# Altium schematic sheet [03] - POWER SUPPLY.SchDoc
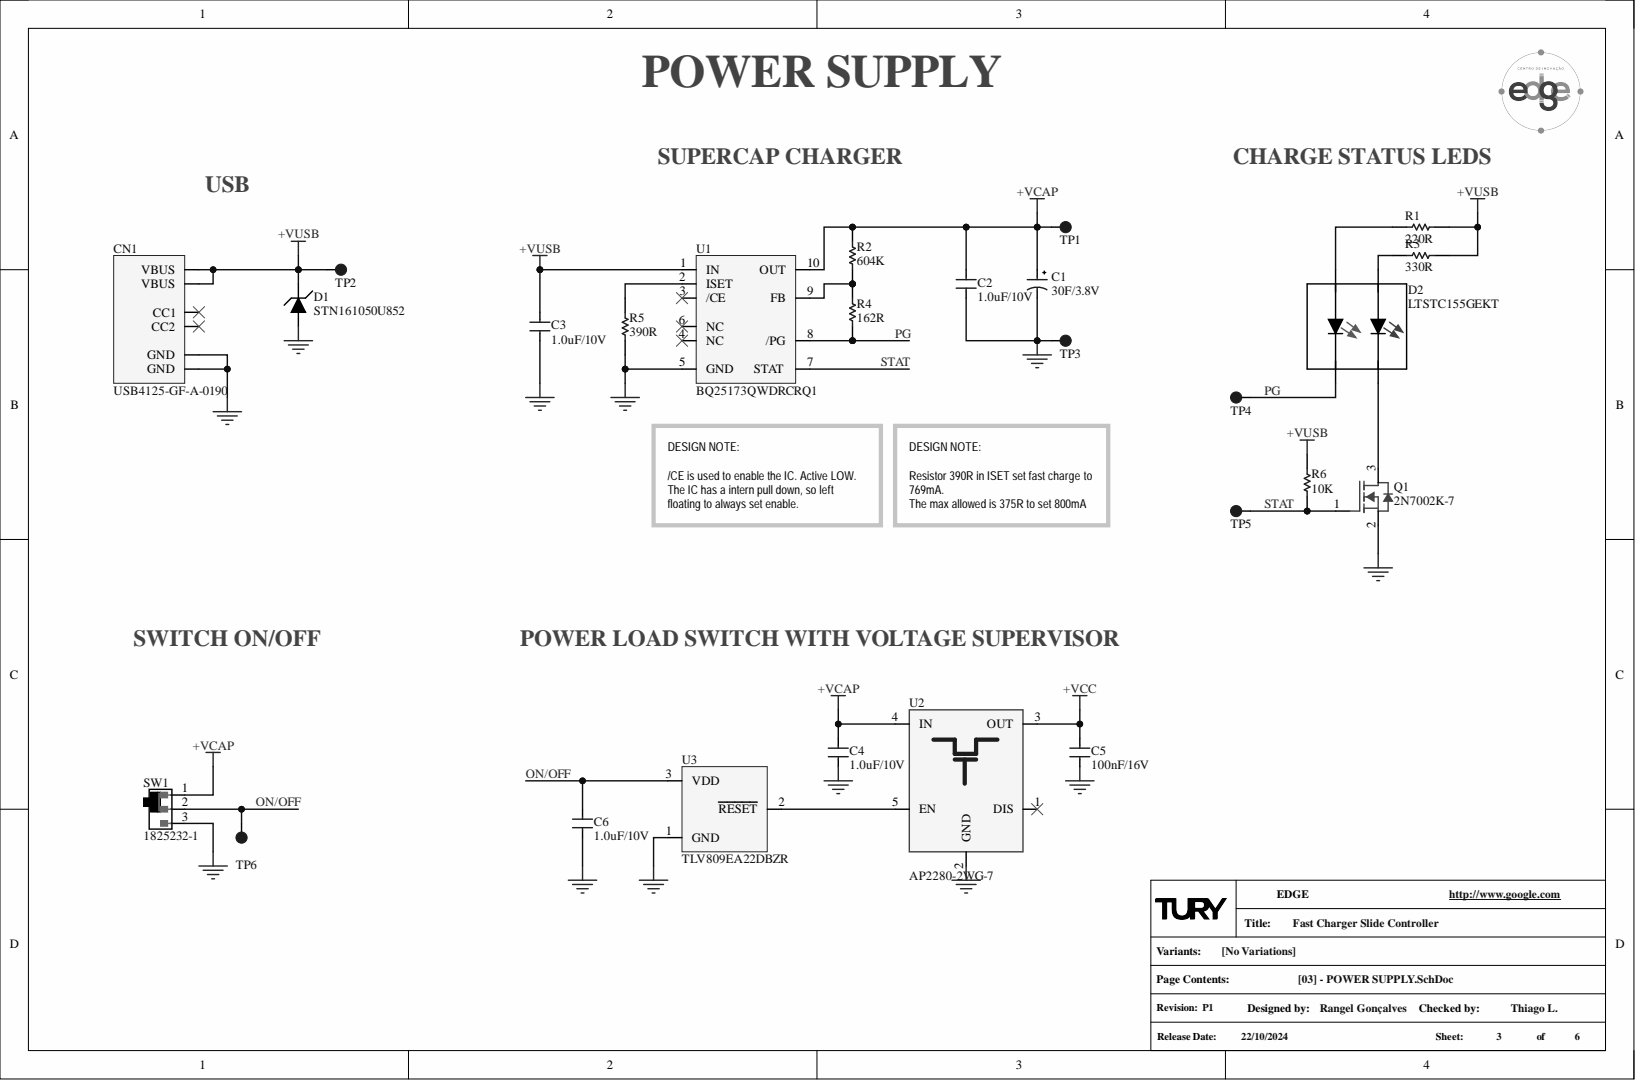
[diagram p0: [03] - POWER SUPPLY.SchDoc]

## Parts
C1  30F/3.8V  [DGH156Q2R7 - plane]
C2  1.0uF/10V  [C0402]
C3  1.0uF/10V  [C0402]
C4  1.0uF/10V  [C0402]
C5  100nF/16V  [C0402]
C6  1.0uF/10V  [C0402]
CN1  USB4125-GF-A-0190  [USB4125-GF-A-0190]
D1  STN161050U852  [STN161050U852]
D2  LTSTC155GEKT  [LTST-C155GEKT]
Q1  2N7002K-7  [SOT90P240X115-3N]
R1  220R  [R0402]
R2  604K  [R0402]
R3  330R  [R0402]
R4  162R  [R0603]
R5  390R  [R0402]
R6  10K  [R0402]
SW1  1825232-1  [1825232-1_TEC]
TP1  +VCAP  [Test Point]  (no BOM)
TP2  +VUSB  [Test Point]  (no BOM)
TP3  GND  [Test Point]  (value not drawn)  (no BOM)
TP4  PG  [Test Point]  (no BOM)
TP5  STAT  [Test Point]  (no BOM)
TP6  ON/OFF  [Test Point]  (no BOM)
U1  BQ25173QWDRCRQ1  [SON50P300X100_HS-11N]
U2  AP2280-2WG-7  [SOT95P285X145-5N]
U3  TLV809EA22DBZR  [SOT90P240X115-3N23]

## Nets
+VCAP: C1.1 C2.1 C4.2 R2.2 SW1.1 TP1.1 U1.10(OUT) U2.4(IN)
+VCC: C5.2 U2.3(OUT)
+VUSB: C3.1 CN1.A9(VBUS) CN1.B9(VBUS) D1.1 R1.2 R3.2 R6.2 TP2.1 U1.1(IN)
GND: C1.2 C2.2 C3.2 C4.1 C5.1 C6.1 CN1.A12(GND) CN1.B12(GND) D1.2 Q1.2 R5.1 SW1.3 TP3.1 U1.5(GND) U2.2(GND) U3.1
ON/OFF: C6.2 SW1.2 TP6.1 U3.3
PG: D2.3 R4.1 TP4.1 U1.8(/PG)
STAT: Q1.1 R6.1 TP5.1 U1.7(STAT)
NetD2_1: D2.1 R1.1
NetD2_2: D2.2 R3.1
NetD2_4: D2.4 Q1.3
NetR2_1: R2.1 R4.2 U1.9(FB)
NetR5_2: R5.2 U1.2(ISET)
NetU2_5: U2.5(EN) U3.2

Not connected: CN1 A5(CC1) B5(CC2); U1 3(/CE) 4(NC) 6(NC); U2 1(DIS)

## Library data of the parts
C1 = TPLC-3R8/30MR10X16: SCAP - 30F 3.8V PTH 20%; Manufacturer 1: Tecate Group; .Mounting: PTH; Component Kind: Standard; ComponentLink1Description: https://www.tecategroup.com/products/data_sheet.php?i=TPLC-3R8/30MR10X16&src-supplier=Digikey; ComponentLink1URL: https://www.tecategroup.com/products/data_sheet.php?i=TPLC-3R8/30MR10X16&src-supplier=Digikey; Manufacturer Part Number 1: TPLC-3R8/30MR10X16; Pricing: 1=3,48, 25=2,6344, 50=2,4104, 100=2,074, 450=1,6256, 950=1,51348, 2400=1,45743, 4900=1,4294 (USD); RoHS: No; Stock: 9186; Supplier 1: Digikey; Supplier Part Number 1: 2085-TPLC-3R8/30MR10X16-ND; Library Name: fast_charger_slide_controller_P2.SchLib
C2-C4 C6 = CL05X105KP5NNNC: MLCC - 1µF 10V 0402 X6R ±10%.; Manufacturer 1: Samsung; .Mounting: SMT; Capacitor Type: Ceramic; Multilayer: Yes; Temperature Characteristics Code: X6S; Tolerance  (fill in as +-): 10%; Value: 1uF; Case/Package: 0402; Category: Ceramic Capacitors; Component Kind: Standard; ComponentLink1Description: https://datasheet.ciiva.com:443/pdfs/VipMasterIC/IC/SEMS/SEMS-S-A0008522793/SEMS-S-A0008522935-1.pdf?src-supplier=IHS+Markit; ComponentLink1URL: https://datasheet.ciiva.com:443/pdfs/VipMasterIC/IC/SEMS/SEMS-S-A0008522793/SEMS-S-A0008522935-1.pdf?src-supplier=IHS+Markit; ComponentLink2Description: http://www.samsungsem.com/kr/support/library/product-catalog/mlcc.jsp?src-supplier=Digikey; ComponentLink2URL: http://www.samsungsem.com/kr/support/library/product-catalog/mlcc.jsp?src-supplier=Digikey; ComponentLink3Description: http://www.samsungsem.com/kr/support/product-search/mlcc/CL05X105KP5NNNC.jsp?src-supplier=Digikey; ComponentLink3URL: http://www.samsungsem.com/kr/support/product-search/mlcc/CL05X105KP5NNNC.jsp?src-supplier=Digikey; ComponentLink4Description: http://www.samsungsem.com/global/front/downloadcms.do?path=%2F%2Fglobal%2F%2Fsupport%2F%2Flibrary%2F%2Fproduct-catalog%2F%2F__icsFiles%2F%2Fafieldfile%2F%2F2014%2F%2F11%2F%2F13&fileName=MLCC.pdf&src-supplier=Avnet; ComponentLink4URL: http://www.samsungsem.com/global/front/downloadcms.do?path=%2F%2Fglobal%2F%2Fsupport%2F%2Flibrary%2F%2Fproduct-catalog%2F%2F__icsFiles%2F%2Fafieldfile%2F%2F2014%2F%2F11%2F%2F13&fileName=MLCC.pdf&src-supplier=Avnet; ComponentLink5Description: https://datasheet.octopart.com/CL05X105KP5NNNC-Samsung-Electro-Mechanics-datasheet-115064993.pdf?src-supplier=Future+Electronics; ComponentLink5URL: https://datasheet.octopart.com/CL05X105KP5NNNC-Samsung-Electro-Mechanics-datasheet-115064993.pdf?src-supplier=Future+Electronics; Dielectric Material: Ceramic; Height: 0.55mm; JESD-609 Code: e3; Length: 1mm; Manufacturer Part Number 1: CL05X105KP5NNNC; Max Operating Temperature: 105°C; Min Operating Temperature: -55°C; Mounting Technology: Surface Mount; Package Shape: Rectangular; Package Style: SMTMeter; Packaging: Tape and Reel; Pins: 2; Pricing: 10000=0,00801 (USD); Rated DC Voltage (URdc): 10V; Reach SVHC Compliant: Yes; RoHS: Yes; RoHS Compliant: Yes; Stock: 10000; Supplier 1: Avnet; Supplier Part Number 1: CL05X105KP5NNNC; Terminal Finish: Nickel; Termination: Wraparound; Tolerance (fill in as +-): 10%; Width: 0.5mm; Library Name: fast_charger_slide_controller_P2.SchLib
C5 = KGM05AR71C104KH: MLCC - 100nF 16V 0402 X7R ±10%.; Manufacturer 1: Kyocera AVX; .Mounting: SMT; .PCBChecked: Lucas Vieira; Category 1: Ceramic Capacitors; Description 1: Cap Cer 0.1UF 16V X7R 0402; Component Kind: Standard; Manufacturer 2: Murata; Manufacturer 3: Taiyo Yuden; Manufacturer Part Number 1: KGM05AR71C104KH; Manufacturer Part Number 2: GRM155R71E104KE14J; Manufacturer Part Number 3: EMK105B7104KV-F; Pricing 1: 1=0,1, 10=0,014, 50=0,008, 100=0,0062, 500=0,00468, 1000=0,00368, 2500=0,00335, 5000=0,00308 (USD); RoHS 1: No; Stock 1: 2329199; Supplier 1: Digikey; Supplier Part Number 1: 478-KGM05AR71C104KHCT-ND; .SCHChecked: Lucas Vieira; Library Name: fast_charger_slide_controller_P2.SchLib
CN1 = USB4125-GF-A-0190: CONN - CONN RCPT USB TYPE C 6 POS; Manufacturer 1: Global Connector Technology; .Mounting: PTH; Category: USB Connectors; Component Kind: Standard; ComponentLink1Description: https://datasheet.octopart.com/USB4125-GF-A-0190-GCT-datasheet-166929327.pdf?src-supplier=TME; ComponentLink1URL: https://datasheet.octopart.com/USB4125-GF-A-0190-GCT-datasheet-166929327.pdf?src-supplier=TME; Manufacturer Part Number 1: USB4125-GF-A-0190; Pricing: 1=0,67, 10=0,586, 25=0,5356, 50=0,5042, 100=0,4832, 250=0,44116, 500=0,42016 (USD); RoHS: No; Stock: 8194; Supplier 1: Digikey; Supplier Part Number 1: 2073-USB4125-GF-A-0190CT-ND; Library Name: fast_charger_slide_controller_P2.SchLib
D1 = STN161050U852: DIODE - TVS ESD Supp., DFN1610-2L, 5 V | Eaton Electronics STN161050U852; Manufacturer 1: Eaton Bussmann; .Mounting: SMT; .PCBChecked: Victor H; Category: TVS Diodes; Component Kind: Standard; Manufacturer Part Number 1: STN161050U852; Pricing 1: 1=0,5, 10=0,356, 100=0,1796, 500=0,15918, 1000=0,12387 (USD); RoHS: No; Stock 1: 9683; Supplier 1: Digikey; Supplier Part Number 1: 283-STN161050U852CT-ND; .SCHChecked: Victor H; Library Name: fast_charger_slide_controller_P2.SchLib
D2 = LTSTC155GEKT: DIODE - LED BI-COLOR GREEN/RED 4-PIN; Manufacturer 1: Vishay Lite-On; .Mounting: SMT; Component Kind: Standard; ComponentLink1Description: https://datasheet.octopart.com/LTST-C155GEKT-Lite-On-datasheet-158360.pdf?src-supplier=Future+Electronics; ComponentLink1URL: https://datasheet.octopart.com/LTST-C155GEKT-Lite-On-datasheet-158360.pdf?src-supplier=Future+Electronics; Manufacturer Part Number 1: LTSTC155GEKT; Pricing: 876=0,1142, 1000=0,0632 (USD); RoHS: No; Stock: 2543; Supplier 1: Arrow Electronics; Supplier Part Number 1: LTST-C155GEKT; Library Name: fast_charger_slide_controller_P2.SchLib
Q1 = 2N7002K-7: TRANS - MOSFET N-Channel 60V 0.3A SOT-23.; Manufacturer 1: Diodes; .Mounting: SMT; Additional Feature: HIGH RELIABILITY; Drain Current-Max (Abs) (ID): 300mA; Drain-source On Resistance-Max: 2R; Feedback Cap-Max (Crss): 5pF; FET Technology: METAL-OXIDE SEMICONDUCTOR; Number of Elements: 1; Operating Mode: ENHANCEMENT MODE; Package Body Material: Plastic; Power Dissipation-Max (Abs): 350mW; Qualification Status: Not Qualified; Time @ Peak Reflow Temperature-Max (s): 40s; Transistor Application: SWITCHING; Transistor Element Material: SILICON; Category: MOSFETs; Component Kind: Standard; ComponentLink1Description: https://datasheet.ciiva.com:443/pdfs/VipMasterIC/IC/DIOD/DIOD-S-A0009689736/DIOD-S-A0009691901-1.pdf?src-supplier=IHS+Markit; ComponentLink1URL: https://datasheet.ciiva.com:443/pdfs/VipMasterIC/IC/DIOD/DIOD-S-A0009689736/DIOD-S-A0009691901-1.pdf?src-supplier=IHS+Markit; ComponentLink2Description: https://datasheet.ciiva.com/1254/ds30896-1254496.pdf?src-supplier=Newark; ComponentLink2URL: https://datasheet.ciiva.com/1254/ds30896-1254496.pdf?src-supplier=Newark; ComponentLink3Description: https://datasheet.ciiva.com/25624/0900766b81151e8c-25624936.pdf?src-supplier=Rs; ComponentLink3URL: https://datasheet.ciiva.com/25624/0900766b81151e8c-25624936.pdf?src-supplier=Rs; ComponentLink4Description: https://datasheet.ciiva.com/4444/ds30896-81375-4444887.pdf?src-supplier=Mouser; ComponentLink4URL: https://datasheet.ciiva.com/4444/ds30896-81375-4444887.pdf?src-supplier=Mouser; ComponentLink5Description: https://datasheet.ciiva.com/15137/getdatasheetpartid-75159-15137378.pdf?src-supplier=Verical; ComponentLink5URL: https://datasheet.ciiva.com/15137/getdatasheetpartid-75159-15137378.pdf?src-supplier=Verical; ComponentLink6Description: https://datasheet.ciiva.com/48532/ds30896-48532645.pdf?src-supplier=Avnet; ComponentLink6URL: https://datasheet.ciiva.com/48532/ds30896-48532645.pdf?src-supplier=Avnet; ComponentLink7Description: https://datasheet.ciiva.com/1188/ds30896-1188422.pdf?src-supplier=Element14; ComponentLink7URL: https://datasheet.ciiva.com/1188/ds30896-1188422.pdf?src-supplier=Element14; ComponentLink8Description: https://datasheet.ciiva.com/16200/ds30896-16200842.pdf?src-supplier=Future+Electronics; ComponentLink8URL: https://datasheet.ciiva.com/16200/ds30896-16200842.pdf?src-supplier=Future+Electronics; Drain Current-Max (ID): 300mA; DS Breakdown Voltage-Min: 60V; JESD-30 Code: R-PDSO-G3; JESD-609 Code: e3; Manufacturer Part Number 1: 2N7002K-7; Max Operating Temperature: 150°C; Moisture Sensitivity Level: 1; Package Shape: Rectangular; Package Style: SMALL OUTLINEMeter; Peak Reflow Temperature (Cel): 260°C; Pins: 3; Polarity/Channel Type: N-CHANNEL; Pricing: 3000=0,0186, 9000=0,0179, 30000=0,0172, 60000=0,0168, 120000=0,0161 (USD); Reach SVHC Compliant: Yes; RoHS: Yes; RoHS Compliant: Yes; Stock: 2232000; Supplier 1: Future Electronics; Supplier Part Number 1: 8672685; Surface Mount: Yes; Terminal Finish: Tin; Terminal Form: Gull Wing; Terminal Position: DUAL; Library Name: fast_charger_slide_controller_P2.SchLib
R1 = CRCW0402220RFKEDC: RES - 220R 1/16W 0402 ±1%; Manufacturer 1: Vishay; .Mounting: SMT; Package Height: 0.3mm; Package Length: 1mm; Package Width: 0.5mm; Power: 63mW; Temperature Coefficient: -100100ppm/°C; Working Voltage: 50V; Case/Package: 0402; Category: Chip SMD Resistors; Component Kind: Standard; ComponentLink1Description: https://datasheet.ciiva.com:443/pdfs/VipMasterIC/IC/VISH/VISH-S-A0003599164/VISH-S-A0003599164-1.pdf?src-supplier=IHS+Markit; ComponentLink1URL: https://datasheet.ciiva.com:443/pdfs/VipMasterIC/IC/VISH/VISH-S-A0003599164/VISH-S-A0003599164-1.pdf?src-supplier=IHS+Markit; ComponentLink2Description: http://www.vishay.com/docs/28773/crcwce3.pdf?src-supplier=Digikey; ComponentLink2URL: http://www.vishay.com/docs/28773/crcwce3.pdf?src-supplier=Digikey; ComponentLink3Description: https://datasheet.octopart.com/CRCW0402220RFKEDC-Vishay-datasheet-100063072.pdf?src-supplier=Future+Electronics; ComponentLink3URL: https://datasheet.octopart.com/CRCW0402220RFKEDC-Vishay-datasheet-100063072.pdf?src-supplier=Future+Electronics; Construction: Chip; JESD-609 Code: e3; Manufacturer Part Number 1: CRCW0402220RFKEDC; Max Operating Temperature: 155°C; Min Operating Temperature: -55°C; Package Style: SMTMeter; Packaging: Tape and Reel; Pins: 2; Pricing: 1=0,1, 10=0,016, 50=0,016, 100=0,009, 1000=0,006, 10000=0,003 (USD); Reach SVHC Compliant: Yes; Resistor Type: FIXED RESISTOR; RoHS: Yes; RoHS Compliant: Yes; Stock: 82052; Supplier 1: Mouser; Supplier Part Number 1: 71-CRCW0402220RFKEDC; Technology: METAL GLAZE/THICK FILM; Terminal Finish: Nickel; Tolerance: 1%; Value: 220R; Library Name: fast_charger_slide_controller_P2.SchLib
R2 = ERJ-2RKF6043X: RES - 604K 1/10W 0402 ±1%; Manufacturer 1: Panasonic; .Mounting: SMT; Max Power Dissipation: 100mW; Temperature Coefficient: 100ppm/°C; Value: 604kR; Voltage Rating: 50V; Case Code (Imperial): 0402; Case Code (Metric): 1005; Case/Package: 0402; Category: Chip SMD Resistors; Component Kind: Standard; ComponentLink1Description: https://datasheet.ciiva.com/22764/1678782-22764925.pdf?src-supplier=Farnell; ComponentLink1URL: https://datasheet.ciiva.com/22764/1678782-22764925.pdf?src-supplier=Farnell; ComponentLink2Description: https://datasheet.ciiva.com/43467/1678782-43467557.pdf?src-supplier=Farnell; ComponentLink2URL: https://datasheet.ciiva.com/43467/1678782-43467557.pdf?src-supplier=Farnell; ComponentLink3Description: https://datasheet.ciiva.com/9180/aoa0000ce2-9180923.pdf?src-supplier=Avnet; ComponentLink3URL: https://datasheet.ciiva.com/9180/aoa0000ce2-9180923.pdf?src-supplier=Avnet; ComponentLink4Description: https://datasheet.ciiva.com/22668/1678782-22668395.pdf?src-supplier=Element14; ComponentLink4URL: https://datasheet.ciiva.com/22668/1678782-22668395.pdf?src-supplier=Element14; ComponentLink5Description: https://datasheet.ciiva.com/16769/70260748-16769957.pdf?src-supplier=Allied+Electronics; ComponentLink5URL: https://datasheet.ciiva.com/16769/70260748-16769957.pdf?src-supplier=Allied+Electronics; ComponentLink6Description: https://datasheet.ciiva.com/16787/70260748-16787162.pdf?src-supplier=Allied+Electronics; ComponentLink6URL: https://datasheet.ciiva.com/16787/70260748-16787162.pdf?src-supplier=Allied+Electronics; Contact Plating: Tin; Features: Automotive AEC-Q200; Height: 0.35mm; Lead Free: Lead Free; Length: 1mm; Manufacturer Part Number 1: ERJ-2RKF6043X; Max Operating Temperature: 155°C; Min Operating Temperature: -55°C; Mounting Technology: Surface Mount; Number of Terminations: 2; Package Quantity: 10000; Packaging: Tape and Reel; Power Rating: 100mW; Pricing: 10000=0,0036, 40000=0,0034, 100000=0,0032, 250000=0,0031, 400000=0,0029 (USD); Ratings: AEC-Q200; Reach SVHC Compliant: Yes; Resistance Tolerance: 1%; Resistor Type: Thick Film; RoHS: Yes; RoHS Compliant: Yes; Series: ERJ; Stock: 160000; Supplier 1: Future Electronics; Supplier Part Number 1: 7126629; Termination: SMD/SMT; Tolerance: 1%; Voltage Rating (DC): 50V; Width: 0.5mm; Library Name: fast_charger_slide_controller_P2.SchLib
R3 = RC0402FR-07330RL: RES - 330R 1/16W 0402 ±1%; Manufacturer 1: Yageo; .Mounting: SMT; Additional Feature: LASER TRIMMABLE; Package Height: 0.35mm; Package Length: 1mm; Package Width: 0.5mm; Power: 62.5mW; Rated Temperature: 70°C; Temperature Coefficient: 100ppm/°C; Working Voltage: 50V; Case/Package: 0402; Category: Chip SMD Resistors; Component Kind: Standard; ComponentLink1Description: https://datasheet.ciiva.com:443/pdfs/VipMasterIC/IC/YAGO/YAGO-S-A0008145938/YAGO-S-A0008145938-1.pdf?src-supplier=IHS+Markit; ComponentLink1URL: https://datasheet.ciiva.com:443/pdfs/VipMasterIC/IC/YAGO/YAGO-S-A0008145938/YAGO-S-A0008145938-1.pdf?src-supplier=IHS+Markit; ComponentLink2Description: https://datasheet.ciiva.com/11819/0900766b80c66297-11819490.pdf?src-supplier=Rs; ComponentLink2URL: https://datasheet.ciiva.com/11819/0900766b80c66297-11819490.pdf?src-supplier=Rs; ComponentLink3Description: https://datasheet.ciiva.com/7849/1018594-7849962.pdf?src-supplier=Element14; ComponentLink3URL: https://datasheet.ciiva.com/7849/1018594-7849962.pdf?src-supplier=Element14; ComponentLink4Description: https://datasheet.ciiva.com/7849/1018594-7849963.pdf?src-supplier=Element14; ComponentLink4URL: https://datasheet.ciiva.com/7849/1018594-7849963.pdf?src-supplier=Element14; ComponentLink5Description: https://datasheet.ciiva.com/12656/pyu-rc0402-51-rohs-l-6-r-12656815.pdf?src-supplier=Mouser; ComponentLink5URL: https://datasheet.ciiva.com/12656/pyu-rc0402-51-rohs-l-6-r-12656815.pdf?src-supplier=Mouser; ComponentLink6Description: https://datasheet.ciiva.com/2453/pyu-r-int-thick-7-2453661.pdf?src-supplier=Digikey; ComponentLink6URL: https://datasheet.ciiva.com/2453/pyu-r-int-thick-7-2453661.pdf?src-supplier=Digikey; ComponentLink7Description: https://datasheet.ciiva.com/2453/pyu-r-int-thick-7-2453662.pdf?src-supplier=Digikey; ComponentLink7URL: https://datasheet.ciiva.com/2453/pyu-r-int-thick-7-2453662.pdf?src-supplier=Digikey; ComponentLink8Description: https://datasheet.ciiva.com/2453/pyu-r-int-thick-7-2453663.pdf?src-supplier=Digikey; ComponentLink8URL: https://datasheet.ciiva.com/2453/pyu-r-int-thick-7-2453663.pdf?src-supplier=Digikey; ComponentLink9Description: https://datasheet.ciiva.com/17083/pyu-rc0402-51-rohs-l-6-r-17083994.pdf?src-supplier=Future+Electronics; ComponentLink9URL: https://datasheet.ciiva.com/17083/pyu-rc0402-51-rohs-l-6-r-17083994.pdf?src-supplier=Future+Electronics; Construction: Chip; JESD-609 Code: e3; Manufacturer Part Number 1: RC0402FR-07330RL; Max Operating Temperature: 155°C; Min Operating Temperature: -55°C; Mounting Technology: Surface Mount; Package Shape: Rectangular; Package Style: SMTMeter; Packaging: Tape and Reel; Pins: 2; Pricing: 10000=0,00085 (USD); Reach SVHC Compliant: Yes; Resistor Type: FIXED RESISTOR; RoHS: Yes; RoHS Compliant: Yes; Stock: 330000; Supplier 1: Avnet; Supplier Part Number 1: RC0402FR-07330RL; Technology: METAL GLAZE/THICK FILM; Terminal Finish: Nickel; Termination: Wraparound; Tolerance: 1%; Value: 330R; Library Name: fast_charger_slide_controller_P2.SchLib
R4 = RMCF0603FT162R: RES - 162R 1/10W 0603 ±1%; Manufacturer 1: Stackpole Electronics; .Mounting: SMT; Package Height: 0.45mm; Package Length: 1.55mm; Package Width: 0.8mm; Power: 100mW; Rated Temperature: 70°C; Reference Standard: AEC-Q200; Temperature Coefficient: 100ppm/°C; Working Voltage: 75V; Case/Package: 0603; Category: Chip SMD Resistors; Component Kind: Standard; ComponentLink1Description: https://datasheet.ciiva.com:443/pdfs/VipMasterIC/IC/SEIE/SEIE-S-A0009541969/SEIE-S-A0009541969-1.pdf?src-supplier=IHS+Markit; ComponentLink1URL: https://datasheet.ciiva.com:443/pdfs/VipMasterIC/IC/SEIE/SEIE-S-A0009541969/SEIE-S-A0009541969-1.pdf?src-supplier=IHS+Markit; ComponentLink2Description: https://www.seielect.com/catalog/sei-rmcf_rmcp.pdf?src-supplier=Digikey; ComponentLink2URL: https://www.seielect.com/catalog/sei-rmcf_rmcp.pdf?src-supplier=Digikey; ComponentLink3Description: https://www.seielect.com/catalog/SEI-Packaging.pdf?src-supplier=Digikey; ComponentLink3URL: https://www.seielect.com/catalog/SEI-Packaging.pdf?src-supplier=Digikey; ComponentLink4Description: https://www.seielect.com/catalog/SEI-RMCF_RMCP.pdf?src-supplier=Avnet; ComponentLink4URL: https://www.seielect.com/catalog/SEI-RMCF_RMCP.pdf?src-supplier=Avnet; ComponentLink5Description: https://datasheet.octopart.com/RMCF0603FT162R-Stackpole-Electronics-datasheet-11547379.pdf?src-supplier=Future+Electronics; ComponentLink5URL: https://datasheet.octopart.com/RMCF0603FT162R-Stackpole-Electronics-datasheet-11547379.pdf?src-supplier=Future+Electronics; ComponentLink6Description: http://www.seielect.com/Catalog/SEI-RMC.pdf?src-supplier=Future+Electronics; ComponentLink6URL: http://www.seielect.com/Catalog/SEI-RMC.pdf?src-supplier=Future+Electronics; Construction: Rectangular; JESD-609 Code: e3; Manufacturer Part Number 1: RMCF0603FT162R; Max Operating Temperature: 155°C; Min Operating Temperature: -55°C; Mounting Technology: Surface Mount; Package Shape: Rectangular; Package Style: SMTMeter; Packaging: Tape and Reel; Pins: 2; Pricing: 1=0,1, 10=0,015, 50=0,009, 100=0,0075, 500=0,00498, 1000=0,00425 (USD); Reach SVHC Compliant: Yes; Resistor Type: FIXED RESISTOR; RoHS: Yes; RoHS Compliant: Yes; Stock: 29546; Supplier 1: Digikey; Supplier Part Number 1: RMCF0603FT162RCT-ND; Technology: METAL GLAZE/THICK FILM; Terminal Finish: Nickel; Termination: Wraparound; Tolerance: 1%; Value: 162R; Library Name: fast_charger_slide_controller_P2.SchLib
R5 = CRCW0402390RFKED: RES - 390R 1/16W 0402 ±1%; Manufacturer 1: Vishay; .Mounting: SMT; Max Power Dissipation: 63mW; Temperature Coefficient: 100ppm/°C; Voltage Rating: 50V; Case Code (Imperial): 0402; Case Code (Metric): 1005; Case/Package: 0402; Category: Chip SMD Resistors; Component Kind: Standard; ComponentLink1Description: https://datasheet.ciiva.com/11811/0900766b80d98824-11811074.pdf?src-supplier=Rs; ComponentLink1URL: https://datasheet.ciiva.com/11811/0900766b80d98824-11811074.pdf?src-supplier=Rs; ComponentLink2Description: https://datasheet.ciiva.com/2463/dcrcwe3-2463266.pdf?src-supplier=Digikey; ComponentLink2URL: https://datasheet.ciiva.com/2463/dcrcwe3-2463266.pdf?src-supplier=Digikey; ComponentLink3Description: https://datasheet.ciiva.com/2463/dcrcwe3-2463267.pdf?src-supplier=Digikey; ComponentLink3URL: https://datasheet.ciiva.com/2463/dcrcwe3-2463267.pdf?src-supplier=Digikey; ComponentLink4Description: https://datasheet.ciiva.com/8940/dcrcwe3-8940680.pdf?src-supplier=Avnet; ComponentLink4URL: https://datasheet.ciiva.com/8940/dcrcwe3-8940680.pdf?src-supplier=Avnet; ComponentLink5Description: https://datasheet.ciiva.com/22682/1912744-22682652.pdf?src-supplier=Element14; ComponentLink5URL: https://datasheet.ciiva.com/22682/1912744-22682652.pdf?src-supplier=Element14; ComponentLink6Description: https://datasheet.ciiva.com/7672/1697337-7672799.pdf?src-supplier=Element14; ComponentLink6URL: https://datasheet.ciiva.com/7672/1697337-7672799.pdf?src-supplier=Element14; ComponentLink7Description: https://datasheet.ciiva.com/22708/1697337-22708415.pdf?src-supplier=Element14; ComponentLink7URL: https://datasheet.ciiva.com/22708/1697337-22708415.pdf?src-supplier=Element14; ComponentLink8Description: https://datasheet.ciiva.com/5550/dcrcwe3-109170-5550511.pdf?src-supplier=Mouser; ComponentLink8URL: https://datasheet.ciiva.com/5550/dcrcwe3-109170-5550511.pdf?src-supplier=Mouser; ComponentLink9Description: https://datasheet.ciiva.com/16776/70201119-16776669.pdf?src-supplier=Allied+Electronics; ComponentLink9URL: https://datasheet.ciiva.com/16776/70201119-16776669.pdf?src-supplier=Allied+Electronics; ComponentLink10Description: https://datasheet.ciiva.com/22872/70201119-22872263.pdf?src-supplier=Allied+Electronics; ComponentLink10URL: https://datasheet.ciiva.com/22872/70201119-22872263.pdf?src-supplier=Allied+Electronics; Construction: Rectangular; Contact Plating: Tin; Depth: 0.5mm; Features: Automotive AEC-Q200; Height: 0.35mm; Lead Free: Lead Free; Length: 1mm; Manufacturer Part Number 1: CRCW0402390RFKED; Max Operating Temperature: 155°C; Military Standard: Not; Min Operating Temperature: -55°C; Number of Terminations: 2; Package Quantity: 10000; Packaging: Tape and Reel; Power Rating: 63mW; Pricing: 1=0,1, 10=0,017, 50=0,017, 100=0,01, 1000=0,008, 10000=0,004 (USD); Radiation Hardening: No; Ratings: AEC-Q200; Reach SVHC Compliant: Unknown; Resistance Tolerance: 1%; Resistor Type: Thick Film; RoHS: Yes; RoHS Compliant: Yes; Series: CRCW; Stock: 39159; Supplier 1: Mouser; Supplier Part Number 1: 71-CRCW0402-390-E3; Technology: THICK FILM; Termination: SMD/SMT; Tolerance: 1%; Value: 390R; Voltage Rating (DC): 50V; Weight: 2.3E-05oz; Width: 0.5mm; Library Name: fast_charger_slide_controller_P2.SchLib
R6 = RK73B1ETTP103J: RES - 10K 1/10W 0402 ±5%; Manufacturer 1: KOA Speer; .Mounting: SMT; Package Height: 0.35mm; Package Length: 1mm; Package Width: 0.5mm; Power: 100mW; Rated Temperature: 70°C; Reference Standard: AEC-Q200; Temperature Coefficient: 200ppm/°C; Value: 10kR; Working Voltage: 50V; Case/Package: 0402; Category: Chip SMD Resistors; Component Kind: Standard; ComponentLink1Description: https://datasheet.ciiva.com:443/pdfs/VipMasterIC/IC/KOAS/KOAS-S-A0009586303/KOAS-S-A0009586303-1.pdf?src-supplier=IHS+Markit; ComponentLink1URL: https://datasheet.ciiva.com:443/pdfs/VipMasterIC/IC/KOAS/KOAS-S-A0009586303/KOAS-S-A0009586303-1.pdf?src-supplier=IHS+Markit; ComponentLink2Description: https://datasheet.ciiva.com/15159/getdatasheetpartid-89073-15159879.pdf?src-supplier=Verical; ComponentLink2URL: https://datasheet.ciiva.com/15159/getdatasheetpartid-89073-15159879.pdf?src-supplier=Verical; Construction: Rectangular; JESD-609 Code: e3; Manufacturer Part Number 1: RK73B1ETTP103J; Max Operating Temperature: 155°C; Min Operating Temperature: -55°C; Mounting Technology: Surface Mount; Package Style: SMTMeter; Packaging: Tape and Reel; Pins: 2; Pricing: 1=0,1, 10=0,017, 50=0,0104, 100=0,0087, 500=0,0058, 1000=0,00494, 5000=0,00355 (USD); Reach SVHC Compliant: Yes; Resistor Type: FIXED RESISTOR; RoHS: Yes; RoHS Compliant: Yes; Stock: 1386278; Supplier 1: Digikey; Supplier Part Number 1: 2019-RK73B1ETTP103JCT-ND; Technology: METAL GLAZE/THICK FILM; Terminal Finish: Nickel; Termination: Wraparound; Tolerance: 5%; Library Name: fast_charger_slide_controller_P2.SchLib
SW1 = 1825232-1: SWITCH SLIDE SPDT 200MA 30V; Manufacturer 1: TE Connectivity AMP; .Mounting: PTH; Actuator Length: 0.118inch; Actuator Material: Nylon; Actuator Type: Standard; Electrical Life: 10000Cycles; Number of Positions: 2; Operating Force: 200gf; PCB Mount Retention: With; Switch Function: On-On; Switch Travel: 2mm; Tail Length: 0.094inch; Category: Slide Switches; Component Kind: Standard; ComponentLink1Description: https://datasheet.ciiva.com/15085/eng-cd-1825232-b2-15085854.pdf?src-supplier=Digi-Key; ComponentLink1URL: https://datasheet.ciiva.com/15085/eng-cd-1825232-b2-15085854.pdf?src-supplier=Digi-Key; Contact Current Rating: 200mA; Contact Material: Copper Alloy; Contact Plating: Silver; Current Rating: 200mA; ELV: Compliant; Flammability Rating: UL94 HB; Length: 0.3563inch; Manufacturer Part Number 1: 1825232-1; Max Operating Temperature: 70°C; Max Voltage Rating (DC): 30V; Mechanical Life: 10000Cycles; Min Operating Temperature: -20°C; Mounting Technology: Through Hole; Orientation: Right Angle; Package Quantity: 19200; Packaging: Tray; Pricing: 1=0,1967, 10=0,1933, 25=0,178, 100=0,1609, 500=0,1357, 800=0,1297, 2400=0,1205, 2500=0,1166 (USD); RoHS: Yes; RoHS Compliant: Yes; Stock: 15720; Supplier 1: Arrow Electronics; Supplier Part Number 1: 1825232-1; Termination: PC Pin; Throw Configuration: SPDT; Type: Switch; Voltage Rating (DC): 30V; Width: 4.3mm; Library Name: fast_charger_slide_controller_P2.SchLib
TP1-TP6 = Test_Point: MECH - Test Points; Library Name: fast_charger_slide_controller.SchLib
U1 = BQ25173QWDRCRQ1: IC - BQ 800-mA programmable charger for supercapacitor; Manufacturer 1: Texas Instruments; .Mounting: SMT; Component Kind: Standard; Height: 1mm; Length: 3mm; Manufacturer Part Number 1: BQ25173QWDRCRQ1; Pins: 10; Pricing: 1=1,33, 10=1,2, 50=1,2, 100=0,93, 1000=0,632, 10000=0,517 (USD); RoHS: No; Stock: 2867; Supplier 1: Mouser; Supplier Part Number 1: 595-BQ25173QWDRCRQ1; Thickness: 900um; Width: 3mm; Library Name: fast_charger_slide_controller_P2.SchLib
U2 = AP2280-2WG-7: IC - Load Switch Power 105 mOhm 2A Single Channel SOT-25; Manufacturer 1: Diodes; .Mounting: SMT; .PCBChecked: Lucas Vieira; Interface: On/Off; Max Power Dissipation: 750mW; Number of Outputs: 1; On-state Resistance-Max: 105MR; Output Configuration: High Side; Output Current: 0A; Voltage: 6V; Case/Package: SOT-25; Category: Hot Swap Controllers; Component Kind: Standard; ComponentLink1Description: https://datasheet.ciiva.com/15109/getdatasheetpartid-496102-15109123.pdf?src-supplier=Verical; ComponentLink1URL: https://datasheet.ciiva.com/15109/getdatasheetpartid-496102-15109123.pdf?src-supplier=Verical; ComponentLink2Description: https://datasheet.ciiva.com/3232/ap2280-3232583.pdf?src-supplier=Avnet; ComponentLink2URL: https://datasheet.ciiva.com/3232/ap2280-3232583.pdf?src-supplier=Avnet; ComponentLink3Description: https://datasheet.ciiva.com/1962/ap2280-1962200.pdf?src-supplier=Digikey; ComponentLink3URL: https://datasheet.ciiva.com/1962/ap2280-1962200.pdf?src-supplier=Digikey; ComponentLink4Description: https://datasheet.ciiva.com/1962/ap2280-1962201.pdf?src-supplier=Digikey; ComponentLink4URL: https://datasheet.ciiva.com/1962/ap2280-1962201.pdf?src-supplier=Digikey; ComponentLink5Description: https://datasheet.ciiva.com/1962/ap2280-1962202.pdf?src-supplier=Digikey; ComponentLink5URL: https://datasheet.ciiva.com/1962/ap2280-1962202.pdf?src-supplier=Digikey; ComponentLink6Description: https://datasheet.ciiva.com/4924/ap2280-4924956.pdf?src-supplier=Mouser; ComponentLink6URL: https://datasheet.ciiva.com/4924/ap2280-4924956.pdf?src-supplier=Mouser; ComponentLink7Description: https://datasheet.ciiva.com/16415/ap2280-16415656.pdf?src-supplier=Future+Electronics; ComponentLink7URL: https://datasheet.ciiva.com/16415/ap2280-16415656.pdf?src-supplier=Future+Electronics; Current: 2A; Height: 1.3mm; Lead Free: Lead Free; Length: 3.1mm; Manufacturer 2: Diodes; Manufacturer 3: Diodes; Manufacturer Part Number 1: AP2280-2WG-7; Manufacturer Part Number 2: AP2280-1WG-7; Manufacturer Part Number 3: AP22804AW5-7; Max Current Rating: 2A; Max Operating Temperature: 85°C; Max Output current: 2A; Min Operating Temperature: -40°C; Mounting Technology: Surface Mount; Package Quantity: 3000; Packaging: Tape and Reel; Pins: 5; Power Dissipation: 750mW; Pricing: 1=0,64, 10=0,56, 25=0,526, 100=0,4293, 250=0,39876, 500=0,33936, 1000=0,27149 (USD); Radiation Hardening: No; Reach SVHC Compliant: Yes; RoHS: Yes; RoHS Compliant: Yes; Series: -; Stock: 270764; Supplier 1: Digikey; Supplier Part Number 1: AP2280-2WGDICT-ND; Weight: 0.001058oz; Width: 1.7mm; .SCHChecked: Lucas Vieira; Library Name: fast_charger_slide_controller_P2.SchLib
U3 = TLV809EA22DBZR: IC - Voltage Supervisor Low Power 1 Channel 2.25V SOT23; Manufacturer 1: Texas Instruments; .Mounting: SMT; Category: Voltage Supervisors; Component Kind: Standard; Manufacturer Part Number 1: TLV809EA22DBZR; Pricing: 1=0,49, 10=0,418, 50=0,418, 100=0,312, 1000=0,189, 10000=0,092 (USD); RoHS: No; RoHS Compliant: Yes; Stock: 4012; Supplier 1: Mouser; Supplier Part Number 1: 595-TLV809EA22DBZR; Library Name: fast_charger_slide_controller_P2.SchLib
